# Altium schematic sheet POWER.SchDoc(POWER)
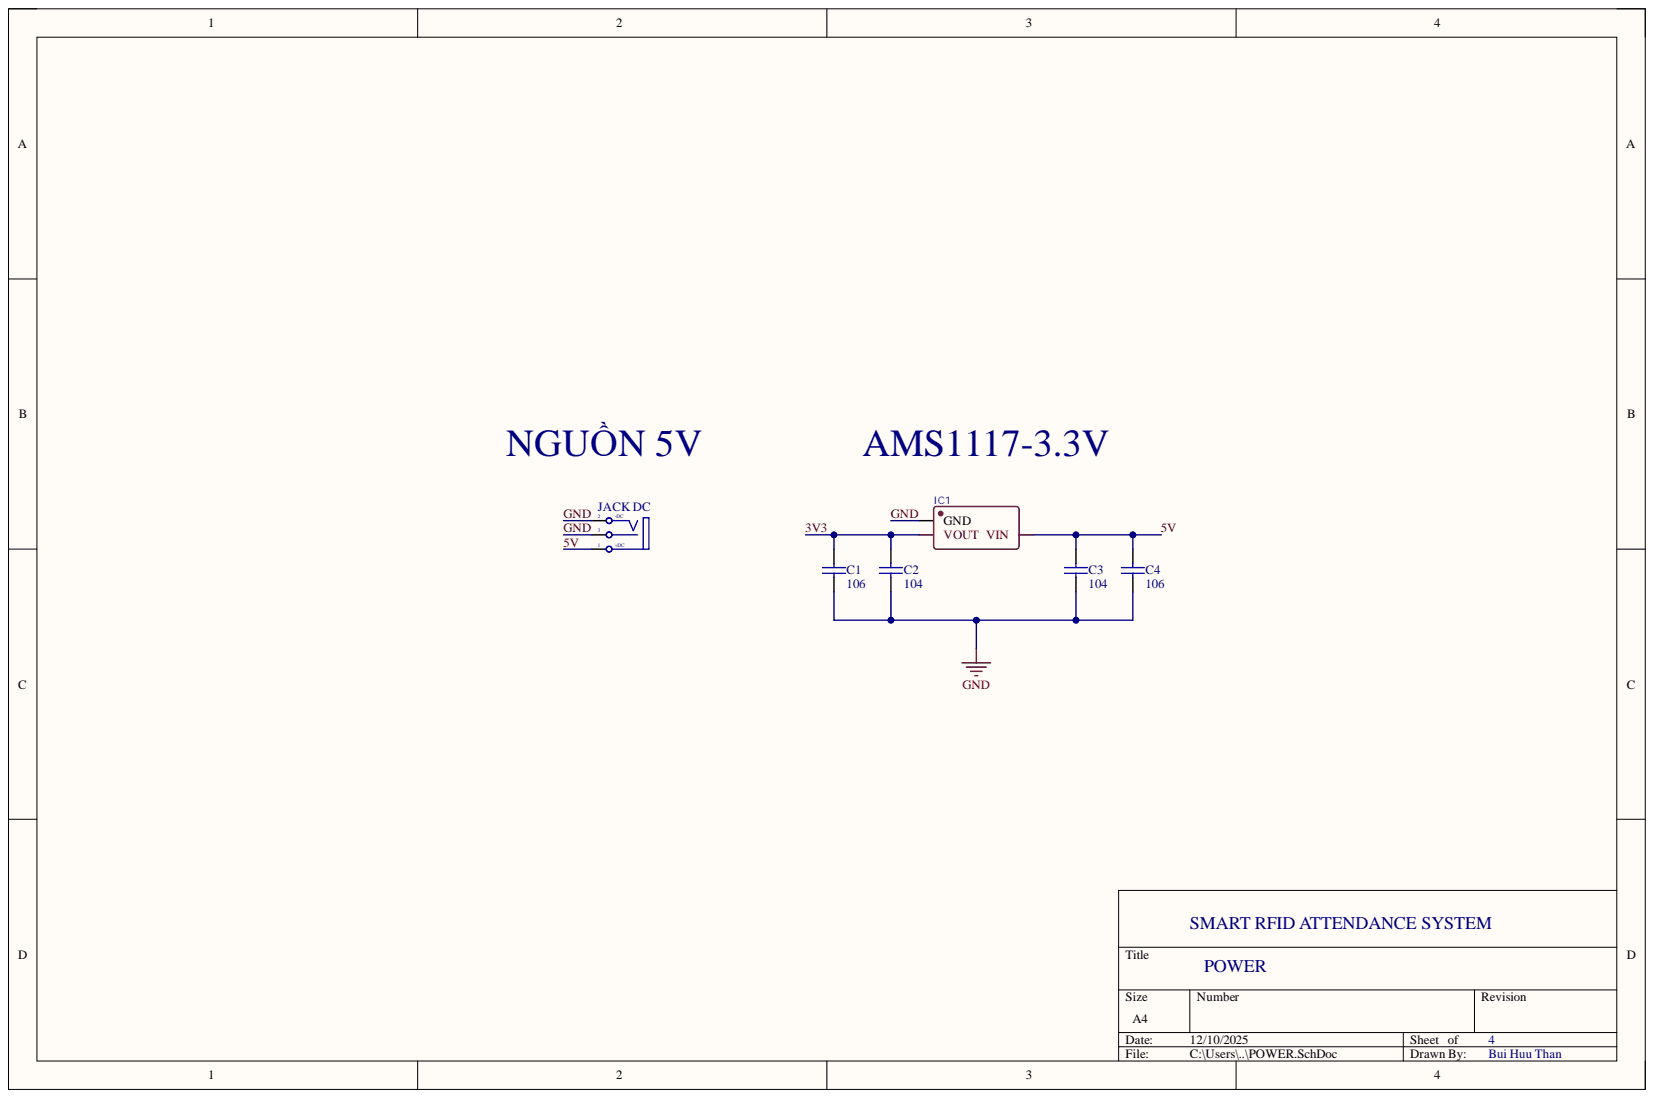
[diagram p0: POWER.SchDoc]

## Parts
C1  106  [tu 0805]
C2  104  [tu 0805]
C3  104  [tu 0805]
C4  106  [tu 0805]
IC1  JTD1117A-3.3V  [TO-252-2_L6.6-W6.1-P4.60-LS10.0-BR-CW]  (value not drawn)
JACK_DC  JACK DC 5.5  [JACK DC 5.5]  (value not drawn)

## Nets
3V3: C1.1 C2.1 IC1.2
5V: C3.1 C4.1 IC1.3 JACK_DC.1
GND: C1.2 C2.2 C3.2 C4.2 IC1.1 JACK_DC.2 JACK_DC.3

## Library data of the parts
C1 C4 = Tu 0805 106 16V 10%: Dán; Don_Vi: Con; Ma_Hang: MH004553; So_Chan: 2; Ten_Linh_Kien: Tụ 0805 106-10uF 10% 16V; Chung_Loai: Dán; Component Kind: Standard; Library Name: Altium_Lib_by_KhueNguyenCreator.IntLib
C2 C3 = Tu 0805 104 50V 10%: Dán; Don_Vi: Con; Ma_Hang: MH004551; So_Chan: 2; Ten_Linh_Kien: Tụ 0805 104-100nF 10% 50V; Chung_Loai: Dán; Component Kind: Standard; Library Name: Altium_Lib_by_KhueNguyenCreator.IntLib
JACK_DC = JACK DC 5.5: Value: Jac DC; Component Kind: Standard; Description: JACK DC 5.5; Library Name: Altium_Lib_by_KhueNguyenCreator.IntLib
IC1 = JTD1117A-3.3V: Component Kind: Standard; Library Name: btl.SchLib
